# Altium schematic sheet Power Sheet.SchDoc
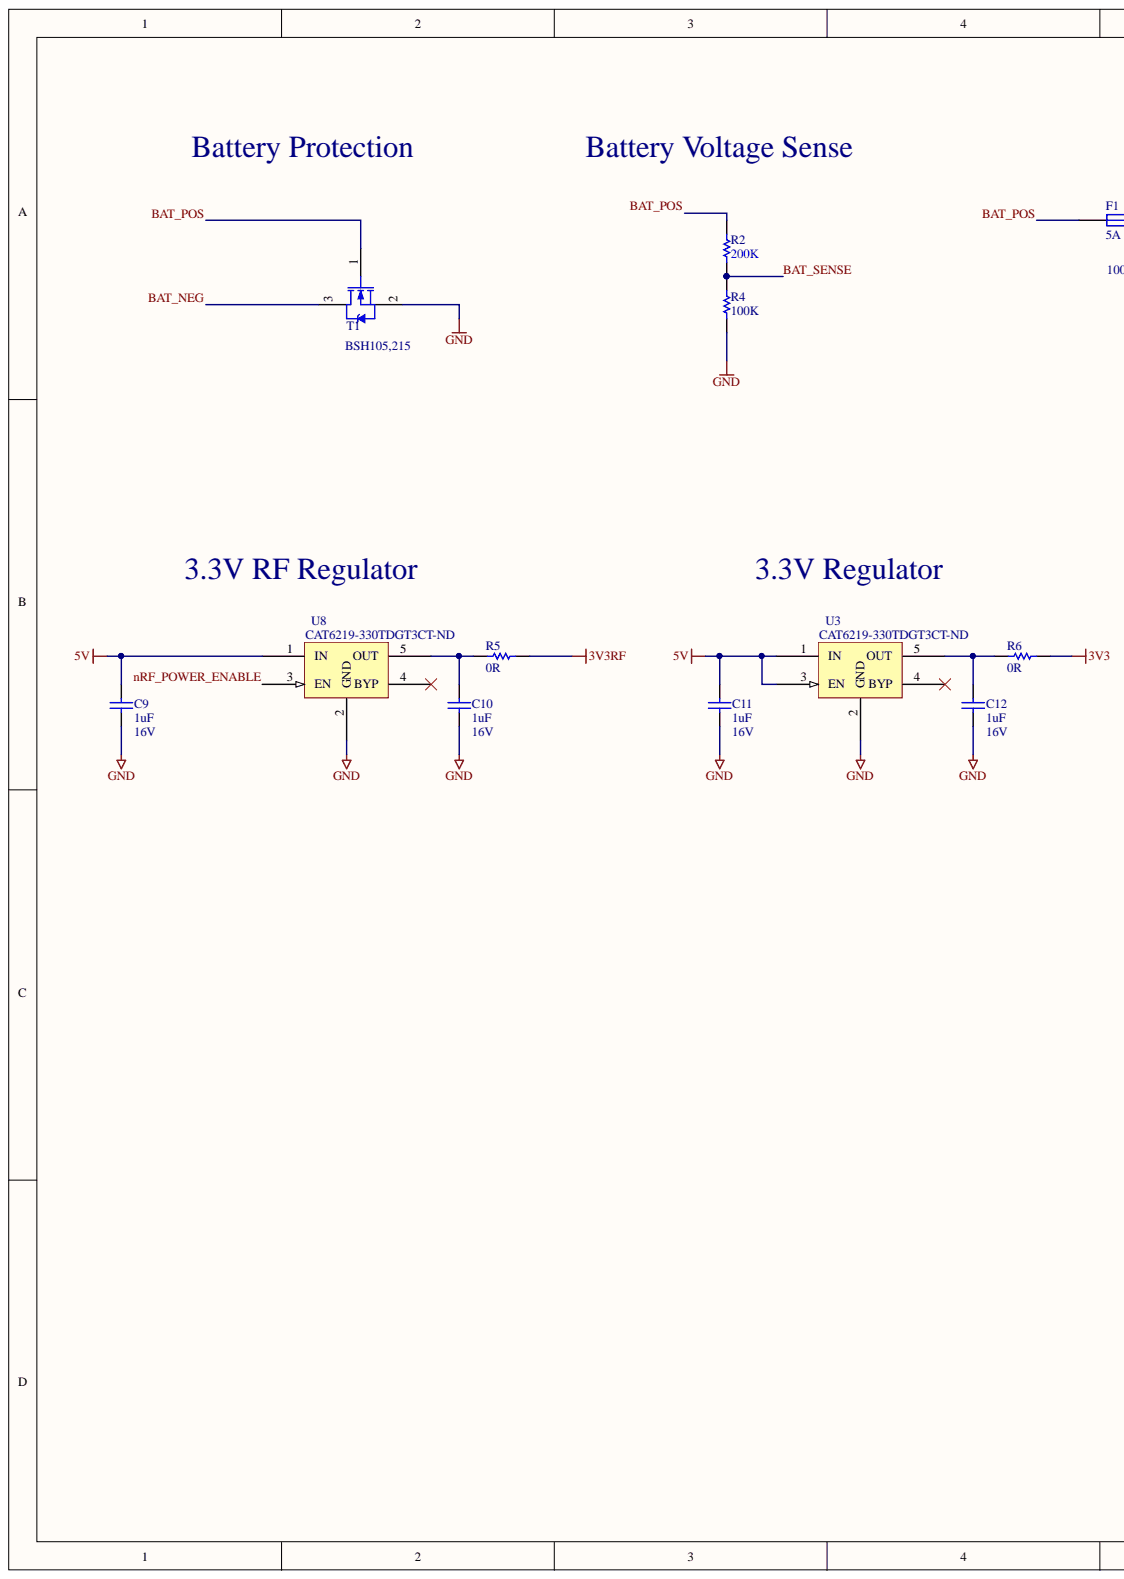
[diagram p0: Power Sheet.SchDoc, left]
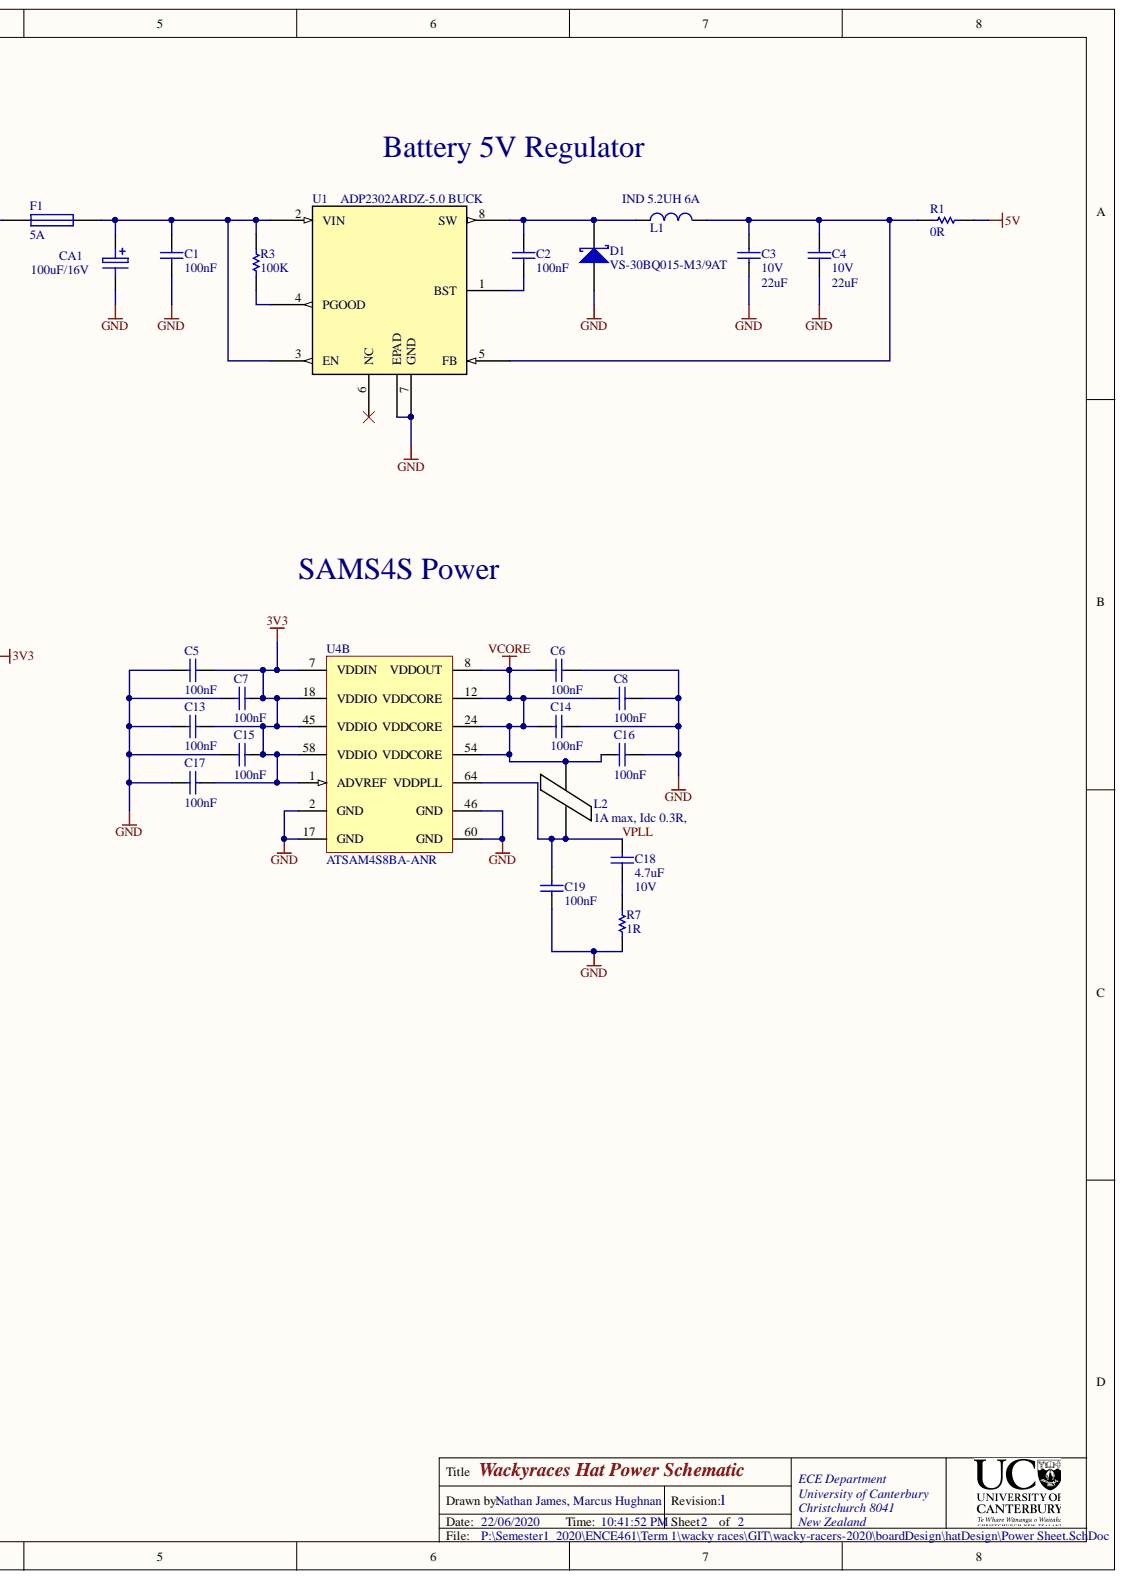
[diagram p1: Power Sheet.SchDoc, right]

## Parts
C1  100nF  [CAPC1608L]
C2  100nF  [CAPC1608L]
C3  22uF  Max Voltage: 10V  [1206 (3216 metric)]
C4  22uF  Max Voltage: 10V  [1206 (3216 metric)]
C5  100nF  [CAPC1608L]
C6  100nF  [CAPC1608L]
C7  100nF  [CAPC1608L]
C8  100nF  [CAPC1608L]
C9  1uF  Max Voltage: 16V  [CAPC1608L]
C10  1uF  Max Voltage: 16V  [CAPC1608L]
C11  1uF  Max Voltage: 16V  [CAPC1608L]
C12  1uF  Max Voltage: 16V  [CAPC1608L]
C13  100nF  [CAPC1608L]
C14  100nF  [CAPC1608L]
C15  100nF  [CAPC1608L]
C16  100nF  [CAPC1608L]
C17  100nF  [CAPC1608L]
C18  4.7uF  Max Voltage: 10V  [CAPC1608N]
C19  100nF  [CAPC1608L]
CA1  100uF/16V  [NICH-1]
D1  VS-30BQ015-M3/9AT  [SMC]
F1  5A  [RESC3216N]
L1  IND 5.2UH 6A  [INDUCTOR5u2]
L2  1A max, Idc 0.3R,  [INDC3216L]
R1  0R  [RESC1608L]
R2  200K  [RESC1608L]
R3  100K  [RESC1608L]
R4  100K  [RESC1608L]
R5  0R  [RESC1608L]
R6  0R  [RESC1608L]
R7  1R  [RESC3216L]
T1  BSH105,215  [SOT23_L]
U1  ADP2302ARDZ-5.0 BUCK  [RD_8_1]
U3  CAT6219-330TDGT3  Supplier Part Number: CAT6219-330TDGT3CT-ND  [SOT95P280X145-5N]
U4  ATSAM4S8BA-ANR  [LQFP64_L]  (part B)
U8  CAT6219-330TDGT3  Supplier Part Number: CAT6219-330TDGT3CT-ND  [SOT95P280X145-5N]

## Nets
3V3: C5.2 C7.2 C13.2 C15.2 C17.2 R6.2 U4.1(ADVREF) U4.7(VDDIN) U4.18(VDDIO) U4.45(VDDIO) U4.58(VDDIO)
3V3RF: R5.2
5V: C9.2 C11.2 R1.2 U3.1(IN) U3.3(EN) U8.1(IN)
GND: C1.1 C3.1 C4.1 C5.1 C6.2 C7.1 C8.2 C9.1 C10.1 C11.1 C12.1 C13.1 C14.2 C15.1 C16.2 C17.1 C19.1 CA1.1 D1.1 R4.1 R7.1 T1.2 U1.7(GND) U1.EPAD U3.2(GND) U4.2(GND) U4.17(GND) U4.46(GND) U4.60(GND) U8.2(GND)
VCORE: C6.1 C8.1 C14.1 C16.1 L2.2 U4.8(VDDOUT) U4.12(VDDCORE) U4.24(VDDCORE) U4.54(VDDCORE)
BAT_NEG: T1.3
BAT_POS: F1.1 R2.2 T1.1
BAT_SENSE: R2.1 R4.2
nRF_POWER_ENABLE: U8.3(EN)
VPLL: C18.2 C19.2 L2.1 U4.64(VDDPLL)
NetC1_2: C1.2 CA1.2 F1.2 R3.1 U1.2(VIN) U1.3(EN)
NetC2_1: C2.1 U1.1(BST)
NetC2_2: C2.2 D1.2 L1.1 U1.8(SW)
NetC3_2: C3.2 C4.2 L1.2 R1.1 U1.5(FB)
NetC10_2: C10.2 R5.1 U8.5(OUT)
NetC12_2: C12.2 R6.1 U3.5(OUT)
NetC18_1: C18.1 R7.2
NetR3_2: R3.2 U1.4(PGOOD)

Not connected: U1 6(NC); U3 4(BYP); U8 4(BYP)

## Library data of the parts
C1 C2 C5-C8 C13-C17 C19 = CC_100nF_0603: CAP CER 100nF X7R +-10% 50V 0603; Code_IPC: 1608 [0603]; Component Kind: Standard; Library Name: ECE AD19Components_19V1.IntLib; PackageReference: 0603; Signal Integrity: C0603; Simulation: CAP; Storage Location: PCB Assembly WS; Supplier: ECE Dept; Value: 100nF
C3 C4 = CC_22uF_1206: CAP CER 22uF Y5V -20% +80% 10V 1206; Component Kind: Standard; Dielectric: Y5V; Library Name: ECE AD19Components_19V1.IntLib; Simulation: CAP; Storage Location: PCB Assembly WS; Supplier: ECE Dept; Value: 22uF
C9-C12 = CC_1uF_0603: CAP CER 1uF Y5V -20% +80% 16V 0603; Code_IPC: 1608 [0603]; Component Kind: Standard; Library Name: ECE AD19Components_19V1.IntLib; PackageReference: 0603; Signal Integrity: C0603; Simulation: CAP; Storage Location: PCB Assembly WS; Supplier: ECE Dept; Value: 1uF
C18 = CC_4.7uF_0603: CAP CER 4.7uF X5R +-20%  10V 0603; Note: Footprint corresponds to dimensions for bulk cassette packaging. This case size has tightened tolerances.; Code_IPC: 2012[0805]; Component Kind: Standard; Library Name: ECE AD19Components_19V1.IntLib; PackageReference: 0805; Simulation: CAP; Storage Location: PCB Assembly WS; Supplier: ECE Dept; Value: 4.7uF
CA1 = CA_100uF_16V: CAP ALUM 100uF 16V SMD; Manufacturer: Nichcon; Manufacturer PN: UWX1C101MCL1GB; Component Kind: Standard; Library Name: ECE AD19Components_19V1.IntLib; Storage Location: PCB Assembly WS; Supplier: ECE Dept; Supplier 1: Farnell; Supplier 2: Digi-Key; Supplier Part Number 1: 1870677; Supplier Part Number 2: 493-2105-1-ND; Value: 100uF/16V
D1 = DS_VS-30BQ015-M3: DIODE, SCHOTTKY, 3A, 15V, DO-214AB / SMC; Manufacturer: Vishay Semiconductors; Forward Voltage: 350mV; Max Forward Surge Current (Ifsm): 650A; Peak Reverse Current: 4mA; Average Rectified Current: 3A; Component Kind: Standard; ComponentLink1Description: https://datasheet.ciiva.com/36181/vs-30bq015-m3-9at-36181258.pdf; ComponentLink1URL: https://datasheet.ciiva.com/36181/vs-30bq015-m3-9at-36181258.pdf; Library Name: ECE AD19Components_19V1.IntLib; Manufacturer Part Number: VS-30BQ015-M3/9AT; Max Reverse Voltage (DC): 15V; Supplier: Digi-Key; Supplier 1: Digi-Key; Supplier 2: Element14; Supplier Part Number: VS-30BQ015-M3/9AT-ND; Supplier Part Number 1: VS-30BQ015-M3/9ATGIDKR-ND; Supplier Part Number 2: 2628288
F1 = FS_Fuse_5.0A: Fuse 5.0A Fast Acting 1206 SMD; Manufacturer: Bel TRP; Manufacturer Part Number: C1F5; Approvals: CE,cURus; DC Cold Resistance: 19mR; Fuse Type: Board Mount (Cartridge Style Excluded); Voltage Rating: 125V; Voltage Rating (DC): 63V; Case/Package: 1206; Case Code (Imperial): 1206; Case Code (Metric): 3216; Component Kind: Standard; ComponentLink1Description: http://www.farnell.com/datasheets/2571625.pdf; ComponentLink1URL: http://www.farnell.com/datasheets/2571625.pdf; ComponentLink2Description: http://belfuse.com/pdfs/C1F.pdf; ComponentLink2URL: http://belfuse.com/pdfs/C1F.pdf; ComponentLink3Description: http://www.mouser.com/ds/2/643/C1F-594910.pdf; ComponentLink3URL: http://www.mouser.com/ds/2/643/C1F-594910.pdf; ComponentLink4Description: https://belfuse.com/resources/StewartConnector/C1F.pdf; ComponentLink4URL: https://belfuse.com/resources/StewartConnector/C1F.pdf; Contact Plating: Nickel,Tin; Current Rating: 5A; Length: 0.126inch; Library Name: ECE AD19Components_19V1.IntLib; Max Operating Temperature: 125°C; Min Operating Temperature: -55°C; Mount: Surface Mount; Number of Pins: 2; Packaging: Tape and Reel; Pricing 1: 1=0.32, 5=0.312, 10=0.298, 50=0.2662, 100=0.2479, 250=0.22032, 500=0.1836, 1000=0.17442 (USD); Stock 1: 725; Supplier 1: Digi-Key; Supplier 2: Element14; Supplier Part Number 1: 507-1886-1-ND; Supplier Part Number 2: 2848595; Value: 5A; Voltage Rating (AC): 125V; Width: 0.062inch
L1 = CDRH104RNP-5R2NC: FIXED IND 5.2UH 6A 22 MOHM SMD; Manufacturer: Sumida America Components Inc.; Current - Saturation: 5.6A; DC Resistance (DCR): 22 mOhm Max; Material - Core: Ferrite; Shielding: Shielded; Tolerance: ±30%; Category: Inductors, Coils, Chokes; Component Kind: Standard; ComponentLink1Description: http://products.sumida.com/products/pdf/CDRH104R.pdf; ComponentLink1URL: http://products.sumida.com/products/pdf/CDRH104R.pdf; Frequency - Self Resonant: -; Height - Seated (Max): 0.157" (4.00mm); Library Name: ENCE461AD19_19V2.IntLib; Manufacturer Part Number: CDRH104RNP-5R2NC; Mounting Type: Surface Mount; Operating Temperature: -40°C ~ 100°C; Package / Case: Nonstandard; Packaging: Cut Tape (CT); Part Status: Active; Pricing 1: 1=2.9, 10=2.678, 100=1.8743, 500=1.5173 (NZD); Q @ Freq: -; Ratings: -; RoHS: RoHS Compliant; Size / Dimension: 0.402" L x 0.394" W (10.20mm x 10.00mm); Stock 1: 3849; Supplier 1: Digi-Key; Supplier Device Package: -; Supplier Part Number 1: 308-2280-1-ND; Type: -
L2 = IN_Ferrite-Bead: Ferrite Bead, Rdc 0.3R, Z100MHZ 600R, Imax 1A, SMD 1206; Manufacturer: FAIR-RITE; Manufacturer Part Number: 2512066017Y1; DC Current Rating: 1; DC Resistance Max: 0.08; Ferrite Case Style: 1206 ^3216 Metric]; Frequency Range: 50MHz to 1; Impedance: 600; Impedance Tolerance: ± 25%; Component Kind: Standard; Ferrite Mounting: SMD; Library Name: ECE AD19Components_19V1.IntLib; Manufacturer PN: 2512066017Y1; Signal Integrity: Inductor Isolated; Storage Location: PCB Assembly WS; Supplier: ECE Dept; Supplier 1: Farnell; Supplier Part Number 1: 1612060; Value: 1A max, Idc 0.3R,
R1 R5 R6 = RS_0R0: RES 0.0 OHM 1/10W 5% 0603 SMD; Component Kind: Standard; Library Name: ECE AD19Components_19V1.IntLib; Signal Integrity: Res; Simulation: RESISTOR; Storage Location: PCB Assembly WS; Supplier: ECE Dept; Value: 0R
R2 = RS_200K: RES 200K OHM 1/10W 5% 0603 SMD; Component Kind: Standard; Library Name: ECE AD19Components_19V1.IntLib; Signal Integrity: Res; Simulation: RES; Storage Location: PCB Assembly WS; Supplier: ECE Dept; Value: 200K
R3 R4 = RS_100K: RES 100K OHM 1/10W 5% 0603 SMD; Component Kind: Standard; Library Name: ECE AD19Components_19V1.IntLib; Signal Integrity: Res; Simulation: RESISTOR; Storage Location: PCB Assembly WS; Supplier: ECE Dept; Value: 100K
R7 = RT_1R: RES 1R OHM 1/4W 5% 1206 SMD; Component Kind: Standard; Library Name: ECE AD19Components_19V1.IntLib; Storage Location: PCB Assembly WS; Supplier: ECE Dept; Value: 1R
T1 = TM_BSH105: MOSFET N-CH 20V 1.05A SOT-23; Manufacturer: NXP Semiconductors; FET Type: MOSFET N-Channel, Metal Oxide; Gate Charge (Qg) @ Vgs: 3.9nC @ 4.5V; Input Capacitance (Ciss) @ Vds: 152pF @ 16V; Power - Max: 417mW; Rds On (Max) @ Id, Vgs: 200 mOhm @ 600mA, 4.5V; Component Kind: Standard; Current - Continuous Drain (Id) @ 25° C: 1.05A; Drain to Source Voltage (Vdss): 20V; Library Name: ECE AD19Components_19V1.IntLib; Manufacturer Part Number: BSH105,215; Package / Case: TO-236-3, SC-59, SOT-23-3; Pricing: 1=0.53, 10=0.42, 25=0.3544, 100=0.2885, 250=0.23896, 500=0.1974, 1000=0.14785 (NZD); Storage Location: PCB Assembly WS; Supplier: ECE Dept; Supplier 1: Farnell; Supplier 2: Digi-Key; Supplier Part Number 1: 1758066; Supplier Part Number 2: 568-6215-1-ND
U1 = ADP2302ARDZ-5.0-R7: IC REG BUCK 5V 2A 8SOIC; Frequency - Switching: 700kHz; Output Type: Fixed; Vendor: Analog Devices Inc; Voltage - Input (Max): 20V; Component Kind: Standard; Current - Output: 2A; Library Name: ENCE461AD19_19V2.IntLib; Manufacturer_Part_Number: ADP2302ARDZ-5.0-R7; Supplier 1: Digi-Key; Supplier Part Number 1: ADP2302ARDZ-5.0-R7CT-ND; Type: DEV; Value: Value; Voltage - Output (Min/Fixed): 5V
U3 U8 = SV_CAT6219-330TDGT3: IC REG LIN 3.3V 500MA TSOT23-5; Voltage - Input (Max): 5.5V; Voltage Dropout (Max): 0.5V @ 500mA; Base Part Number: CAT6219; Component Kind: Standard; ComponentLink1Description: http://www.onsemi.com/pub/Collateral/CAT6219-D.PDF; ComponentLink1URL: http://www.onsemi.com/pub/Collateral/CAT6219-D.PDF; Current - Output: 500mA; Library Name: ENCE461AD19_19V2.IntLib; Manufacturer Part Number: CAT6219-330TDGT3; Package / Case: SOT-23-5 Thin, TSOT-23-5; Supplier: Digi-Key; Supplier 1: Digi-Key; Supplier Device Package: TSOT-23-5; Voltage - Output (Min/Fixed): 3.3V
U4 = SE_ATSAM4S16BA-MU: IC MCU 32BIT 512KB FLASH 64LQFP; Manufacturer: Atmel; Connectivity: I²C, IrDA, Memory Card, SPI, SSC, UART/USART, USB; Core Processor: ARM® Cortex®-M4; Core Size: 32-Bit; Data Converters: A/D 11x12b, D/A 2x12b; Number of I/O: 47; Peripherals: Brown-out Detect/Reset, DMA, POR, PWM, WDT; Program Memory Size: 512KB (512K x 8); RAM Size: 128K x 8; Speed: 120MHz; Voltage - Supply (Vcc/Vdd): 1.62 V ~ 3.6 V; Code_JEDEC: MO-220VMMD-3; Component Kind: Standard; ComponentLink1Description: Manufacturer URL; ComponentLink1URL: http://www.atmel.com/; ComponentLink2Description: SAM4S Series Datasheet; ComponentLink2URL: http://www.atmel.com/dyn/resources/prod_documents/11100.pdf; ComponentLink3Description: SAM4S Series Summary; ComponentLink3URL: http://www.atmel.com/dyn/resources/prod_documents/11100S.pdf; Library Name: ECE AD19Components_19V1.IntLib; Manufacturer Part Number: ATSAM4S8BA-ANR; Package / Case: 64-LQFP; PackageDescription: 64-Pin Quad Flat No-Lead (QFN) Sawn, 9 x 9 x 1.0 mm Body, 0.5 mm Pitch, Exposed Pad; PackageReference: 64PS; PackageVersion: revJ, Jul-2007; Packaging: Cut Tape (CT); Program Memory Type: FLASH; Supplier 1: Digi-Key; Supplier Part Number 1: ATSAM4S8BA-ANRCT-ND
